# Altium schematic sheet Debug.SchDoc
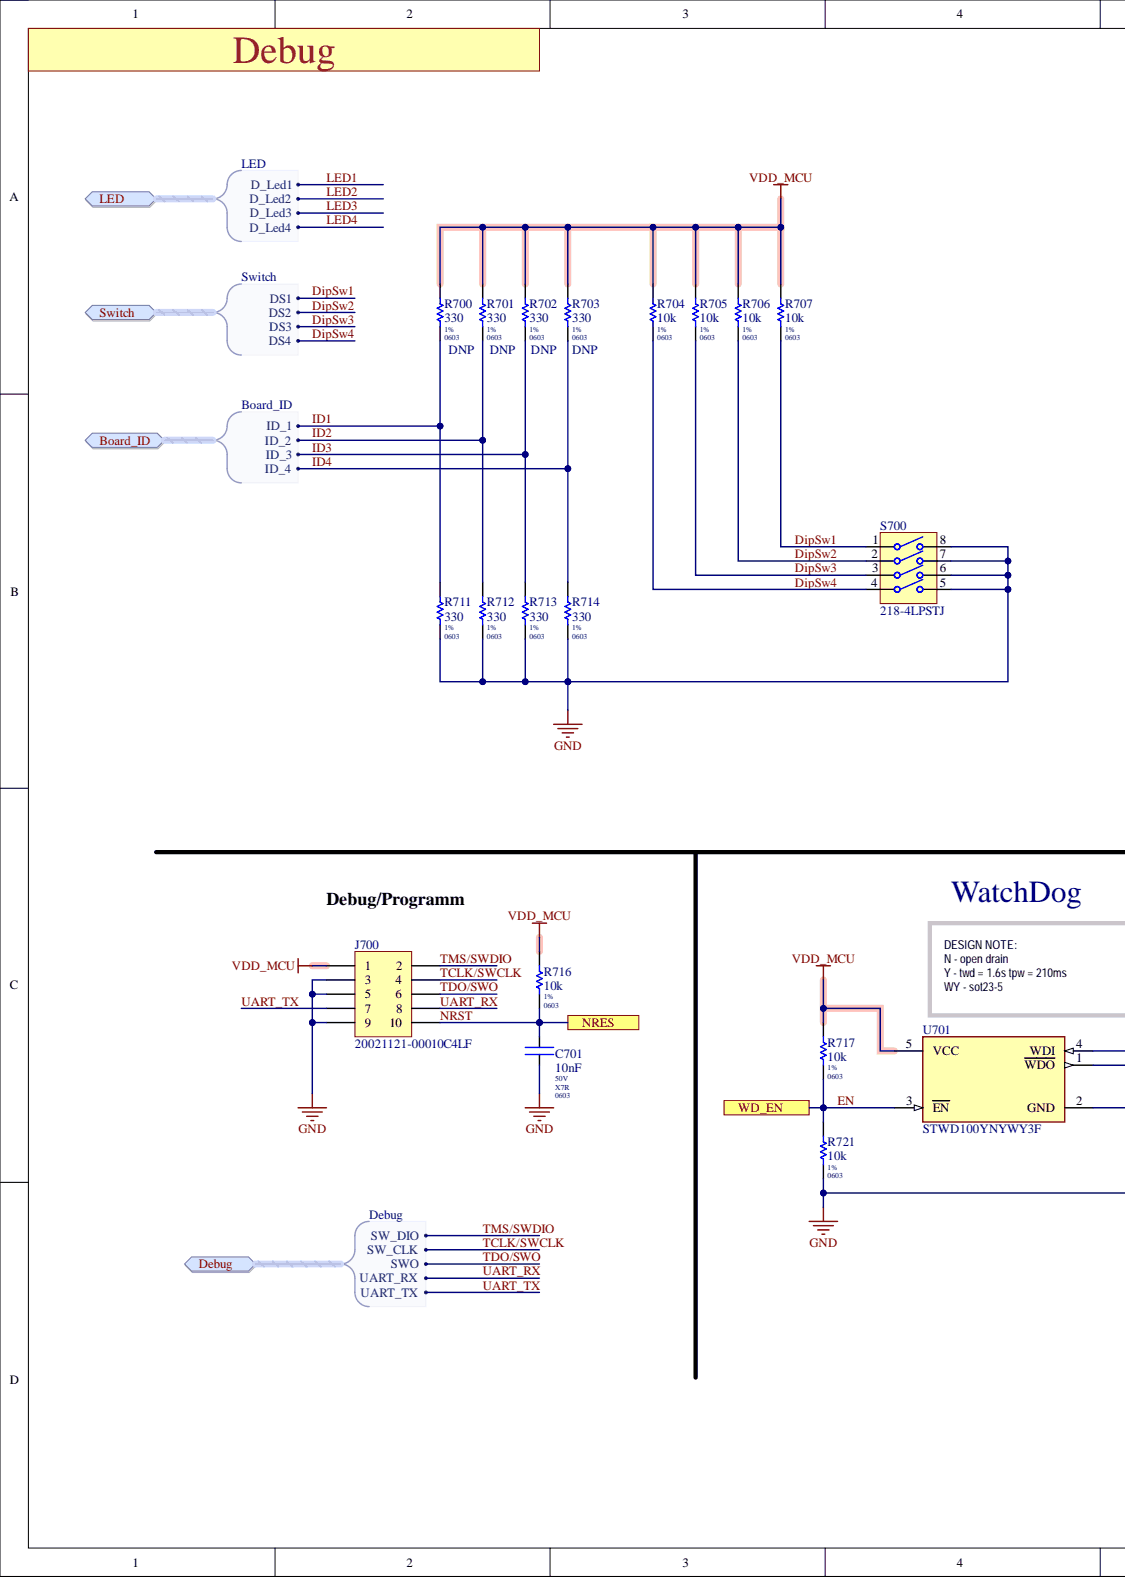
[diagram p0: Debug.SchDoc, left]
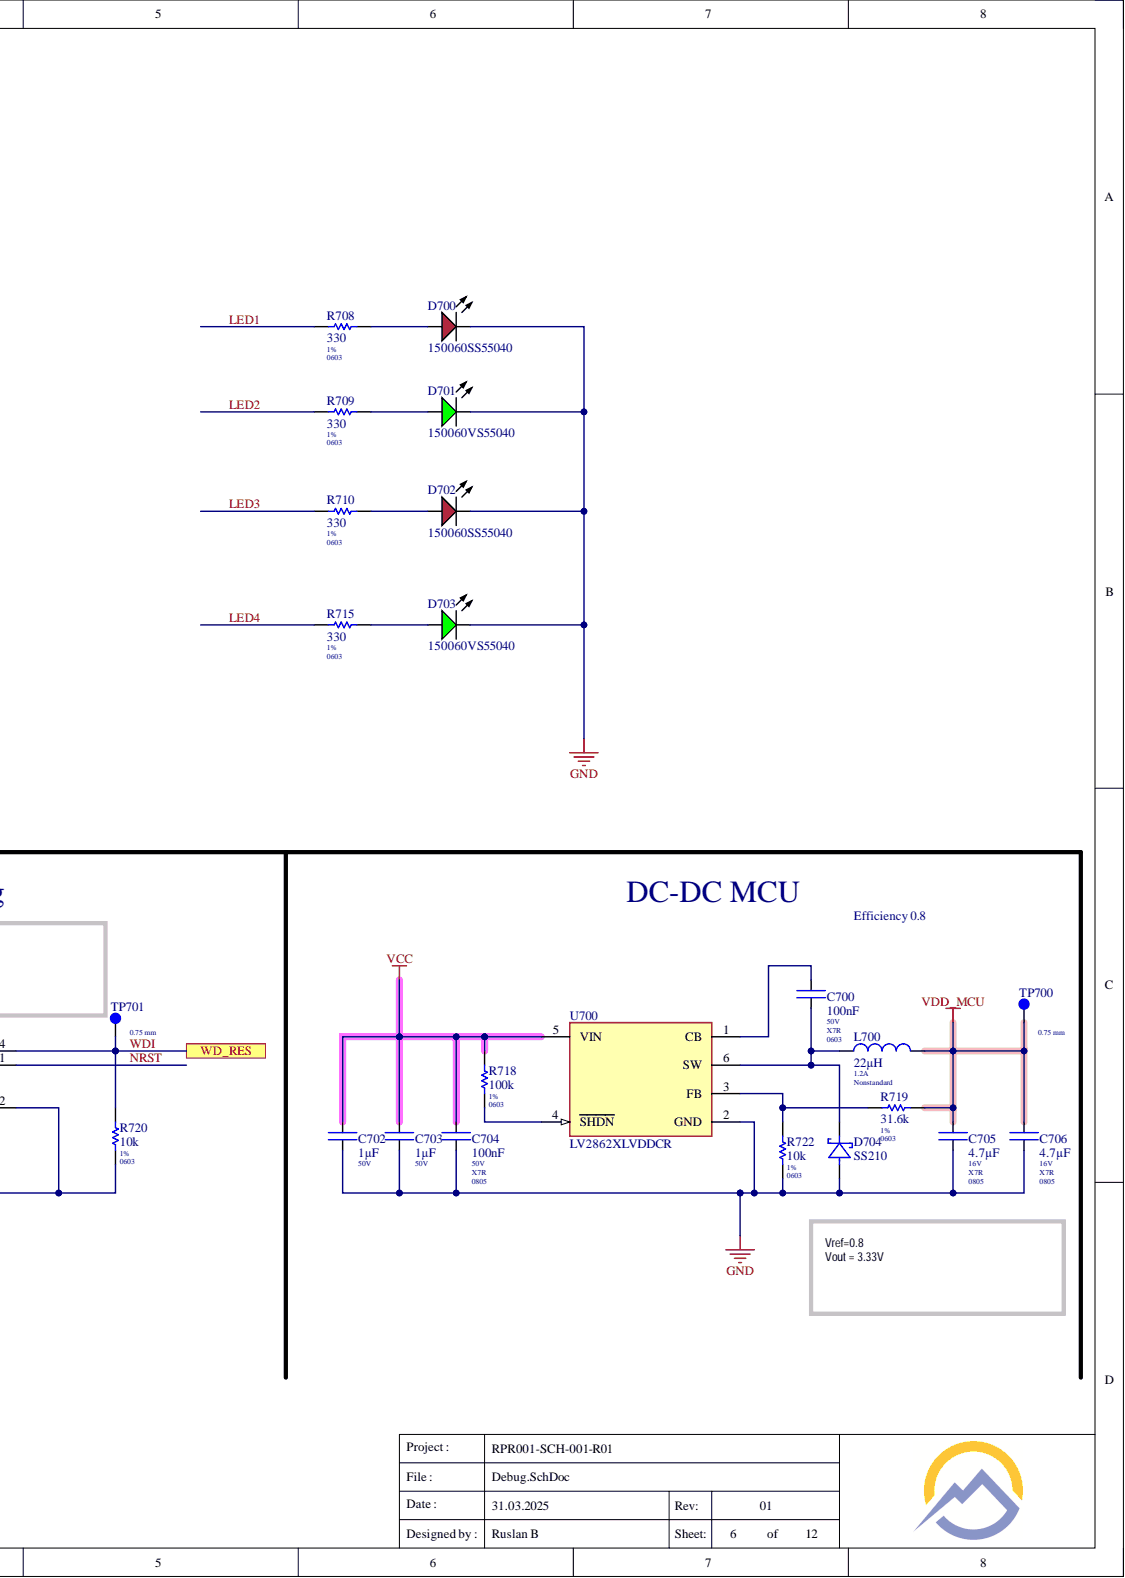
[diagram p1: Debug.SchDoc, right]

## Parts
C700  value 100nF  Voltage Rating: 50V  [B_Cap_0603_KEM]  (hidden comment: GCM188R71H104KA57D)
C701  value 10nF  Case/Package: 0603  Dielectric: X7R  Voltage Rating: 50V  [B_Cap_0603_KEM]  (hidden comment: CC0603MRX7R9BB103)
C702  value 1µF  Voltage Rating: 50V  [B_Cap_0805_KEM]  (hidden comment: CL21B105KBFNNNG)
C703  value 1µF  Voltage Rating: 50V  [B_Cap_0805_KEM]  (hidden comment: CL21B105KBFNNNG)
C704  value 100nF  Case/Package: 0805  Dielectric: X7R  Voltage Rating: 50V  [B_Cap_0805_KEM]  (hidden comment: CC0805KRX7R9BB104)
C705  value 4.7µF  Case/Package: 0805  Dielectric: X7R  Voltage Rating: 16V  [B_Cap_0805_KEM]  (hidden comment: GRM21BR71C475KE51L)
C706  value 4.7µF  Case/Package: 0805  Dielectric: X7R  Voltage Rating: 16V  [B_Cap_0805_KEM]  (hidden comment: GRM21BR71C475KE51L)
D700  150060SS55040  [LED_0603]
D701  150060VS55040  [LED_0603]
D702  150060SS55040  [LED_0603]
D703  150060VS55040  [LED_0603]
D704  SS210  [DO-214AA, SMB]
J700  20021121-00010C4LF  [20021121-00010C4LF-Footprint-2]
L700  value 22µH  Current Rating: 1.2A  [CDRH6D28NP]  (hidden comment: CDRH6D28NP-220NC)
R700  value 330  Assembly: DNP  Case/Package: 0603  Tolerance: 1%  [Res_0603_YAG]  (hidden comment: RC0603FR-07330RL)
R701  value 330  Assembly: DNP  Case/Package: 0603  Tolerance: 1%  [Res_0603_YAG]  (hidden comment: RC0603FR-07330RL)
R702  value 330  Assembly: DNP  Case/Package: 0603  Tolerance: 1%  [Res_0603_YAG]  (hidden comment: RC0603FR-07330RL)
R703  value 330  Assembly: DNP  Case/Package: 0603  Tolerance: 1%  [Res_0603_YAG]  (hidden comment: RC0603FR-07330RL)
R704  value 10k  Case/Package: 0603  Tolerance: 1%  [Res_0603_YAG]  (hidden comment: RC0603FR-0710KL)
R705  value 10k  Case/Package: 0603  Tolerance: 1%  [Res_0603_YAG]  (hidden comment: RC0603FR-0710KL)
R706  value 10k  Case/Package: 0603  Tolerance: 1%  [Res_0603_YAG]  (hidden comment: RC0603FR-0710KL)
R707  value 10k  Case/Package: 0603  Tolerance: 1%  [Res_0603_YAG]  (hidden comment: RC0603FR-0710KL)
R708  value 330  Case/Package: 0603  Tolerance: 1%  [Res_0603_YAG]  (hidden comment: RC0603FR-07330RL)
R709  value 330  Case/Package: 0603  Tolerance: 1%  [Res_0603_YAG]  (hidden comment: RC0603FR-07330RL)
R710  value 330  Case/Package: 0603  Tolerance: 1%  [Res_0603_YAG]  (hidden comment: RC0603FR-07330RL)
R711  value 330  Case/Package: 0603  Tolerance: 1%  [Res_0603_YAG]  (hidden comment: RC0603FR-07330RL)
R712  value 330  Case/Package: 0603  Tolerance: 1%  [Res_0603_YAG]  (hidden comment: RC0603FR-07330RL)
R713  value 330  Case/Package: 0603  Tolerance: 1%  [Res_0603_YAG]  (hidden comment: RC0603FR-07330RL)
R714  value 330  Case/Package: 0603  Tolerance: 1%  [Res_0603_YAG]  (hidden comment: RC0603FR-07330RL)
R715  value 330  Case/Package: 0603  Tolerance: 1%  [Res_0603_YAG]  (hidden comment: RC0603FR-07330RL)
R716  value 10k  Case/Package: 0603  Tolerance: 1%  [Res_0603_YAG]  (hidden comment: RC0603FR-0710KL)
R717  value 10k  Case/Package: 0603  Tolerance: 1%  [Res_0603_YAG]  (hidden comment: RC0603FR-0710KL)
R718  value 100k  Case/Package: 0603  Tolerance: 1%  [Res_0603_YAG]  (hidden comment: RMCF0603FT100K)
R719  value 31.6k  [Res_0603_YAG]  (hidden comment: RMCF0603FT31K6)
R720  value 10k  Case/Package: 0603  Tolerance: 1%  [Res_0603_YAG]  (hidden comment: RC0603FR-0710KL)
R721  value 10k  Case/Package: 0603  Tolerance: 1%  [Res_0603_YAG]  (hidden comment: RC0603FR-0710KL)
R722  value 10k  Case/Package: 0603  Tolerance: 1%  [Res_0603_YAG]  (hidden comment: RC0603FR-0710KL)
S700  218-4LPSTJ  [218-4LPSTJ]
TP700  0.75 mm  [0.75mm]  (no BOM)
TP701  0.75 mm  [0.75mm]  (no BOM)
U700  LV2862XLVDDCR  [SOT-23-6]
U701  STWD100YNYWY3F  [SOT23-5 ST]

## Ports
Board_ID, Board_ID.ID_1, Board_ID.ID_2, Board_ID.ID_3, Board_ID.ID_4, Debug, Debug.SW_CLK, Debug.SW_DIO, Debug.SWO, Debug.UART_RX, Debug.UART_TX, LED, LED.D_Led1, LED.D_Led2, LED.D_Led3, LED.D_Led4, NRES, Switch, Switch.DS1, Switch.DS2, Switch.DS3, Switch.DS4, WD_EN, WD_RES

## Nets
GND: C701.1 C702.2 C703.2 C704.1 C705.1 C706.1 D700.1 D701.1 D702.1 D703.1 D704.2 J700.3 J700.5 J700.9 R711.1 R712.1 R713.1 R714.1 R720.1 R721.1 R722.1 S700.5 S700.6 S700.7 S700.8 U700.2(GND) U701.2(GND)
VCC: C702.1 C703.1 C704.2 R718.2 U700.5(VIN)
VDD_MCU: C705.2 C706.2 J700.1 L700.2 R700.2 R701.2 R702.2 R703.2 R704.2 R705.2 R706.2 R707.2 R716.2 R717.2 R719.2 TP700.1 U701.5(VCC)
DipSw1: R707.1 S700.1 ; port Switch
DipSw2: R706.1 S700.2 ; port Switch
DipSw3: R705.1 S700.3 ; port Switch
DipSw4: R704.1 S700.4 ; port Switch
EN: R717.1 R721.2 U701.3(EN) ; port WD_EN
ID1: R700.1 R711.2 ; port Board_ID
ID2: R701.1 R712.2 ; port Board_ID
ID3: R702.1 R713.2 ; port Board_ID
ID4: R703.1 R714.2 ; port Board_ID
LED1: R708.1 ; port LED
LED2: R709.1 ; port LED
LED3: R710.1 ; port LED
LED4: R715.1 ; port LED
NRST: C701.2 J700.10 R716.1 U701.1(WDO) ; port NRES
TCLK/SWCLK: J700.4 ; port Debug
TDO/SWO: J700.6 ; port Debug
TMS/SWDIO: J700.2 ; port Debug
UART_RX: J700.8 ; port Debug
UART_TX: J700.7 ; port Debug
WDI: R720.2 TP701.1 U701.4(WDI) ; port WD_RES
NetC700_1: C700.1 D704.1 L700.1 U700.6(SW)
NetC700_2: C700.2 U700.1(CB)
NetD700_2: D700.2 R708.2
NetD701_2: D701.2 R709.2
NetD702_2: D702.2 R710.2
NetD703_2: D703.2 R715.2
NetR718_1: R718.1 U700.4(SHDN)
NetR719_1: R719.1 R722.2 U700.3(FB)

## Library data of the parts
C700 = CMP-CAP-CER-00027-13: CAP CER 0.1UF 50V X7R 0603; Manufacturer 1: Murata; Tolerance: ±10%; Case/Package: 0603; Component Kind: Standard; ComponentLink1Description: Datasheet; ComponentLink1URL: https://search.murata.co.jp/Ceramy/image/img/A01X/G101/ENG/GCM188R71H104KA57-01.pdf; Dielectric: X7R; Library Name: <Company> Server; Manufacturer Part Number 1: GCM188R71H104KA57D
C701 = CMP-CAP-CER-00261-3: 0603 10 nF 50 V ±20% Tolerance X7R SMT Multilayer Ceramic Capacitor; Manufacturer 1: Yageo; Component Kind: Standard; Library Name: <Company> Server; Manufacturer Part Number 1: CC0603MRX7R9BB103; Tolerance: 20%
C702 C703 = CMP-CAP-CER-00135-12: CAP CER 1UF 50V X7R 0805; Manufacturer 1: Samsung; Tolerance: ±10%; Component Kind: Standard; ComponentLink1Description: Datasheet; ComponentLink1URL: http://www.samsungsem.com/kr/support/product-search/mlcc/CL21B105KBFNNNG.jsp; Library Name: <Company> Server; Manufacturer Part Number 1: CL21B105KBFNNNG
C704 = CMP-CAP-CER-00029-14: Multilayer Ceramic Capacitor, 0.1 uF, 50 V, ï¿½ 10%, X7R, 0805 [2012 Metric]; Manufacturer 1: YAGEO; Component Kind: Standard; Library Name: <Company> Server; Manufacturer Part Number 1: CC0805KRX7R9BB104; Tolerance: 10%
C705 C706 = CMP-CAP-CER-00204-11: CAP CER 4.7UF 16V X7R 0805; Manufacturer 1: Murata; Tolerance: ±10%; Component Kind: Standard; ComponentLink1Description: Datasheet; ComponentLink1URL: https://search.murata.co.jp/Ceramy/image/img/A01X/G101/ENG/GRM21BR71C475KE51-01.pdf; Library Name: <Company> Server; Manufacturer Part Number 1: GRM21BR71C475KE51L
D700 D702 = CMP-OPTE-LED-00052-3: Led Red Diffused 0603 SMD; Manufacturer 1: Wurth Electronics; Dominant Wavelength: 630nm; Forward Voltage: 2V; Lens Style: Flat; Luminous Intensity: 70mcd; Viewing Angle: 140°; Case/Package: 0603; Color: Red; Component Kind: Standard; ComponentLink1Description: Datasheet; ComponentLink1URL: https://www.we-online.de/katalog/datasheet/150060RS75000.pdf; Library Name: <Company> Server; Manufacturer Part Number 1: 150060SS55040
D701 D703 = CMP-OPTE-LED-00055-3: Led Green Diffused 0603 SMD; Manufacturer 1: Wurth Electronics; Dominant Wavelength: 573nm; Forward Voltage: 2V; Lens Style: Flat; Luminous Intensity: 60mcd; Viewing Angle: 140°; Case/Package: 0603; Color: Green; Component Kind: Standard; ComponentLink1Description: Datasheet; ComponentLink1URL: https://www.rohm.com/datasheet/SML-D12V8W; Library Name: <Company> Server; Manufacturer Part Number 1: 150060VS55040
D704 = CMP-DIO-SCH-00037-8: DIODE SCHOTTKY 100V 2A SMA; Manufacturer 1: Taiwan Semiconductor; Forward Voltage: 850mV; Max Reverse Current: 100µA; Average Rectified Current: 2A; Case/Package: DO-214AA; Component Kind: Standard; ComponentLink1Description: Datasheet; ComponentLink1URL: http://www.smc-diodes.com/propdf/SS22A%20THRU%20SS225A%20N1816%20REV.A.pdf; Forward Current: 2A; Library Name: <Company> Server; Manufacturer Part Number 1: SS210; Max Reverse Voltage (DC): 100V
J700 = CMP-CON-HEAD-00050-8: CONN HEADER SMD 10POS 1.27MM; Manufacturer 1: Amphenol ICC / FCI; Current Rating: 1A; Gender: Male; Number of Contacts: 10; Component Kind: Standard; ComponentLink1Description: Datasheet; ComponentLink1URL: https://media.digikey.com/pdf/Data%20Sheets/FCI%20PDFs/Minitek%20127_Catalog.pdf; Library Name: <Company> Server; Manufacturer Part Number 1: 20021121-00010C4LF; Number of Positions: 10; Number of Rows: 2; Pitch: 1.27mm
L700 = CMP-IND-00067-9: FIXED IND 22UH 1.2A 128 MOHM SMD; Manufacturer 1: Sumida; DC Resistance (DCR): 0.128; Shielding: Shielded; Tolerance: 30%; Case/Package: Nonstandard; Component Kind: Standard; ComponentLink1Description: Datasheet; ComponentLink1URL: http://products.sumida.com/products/pdf/CDRH6D28.pdf; Library Name: <Company> Server; Manufacturer Part Number 1: CDRH6D28NP-220NC
R700-R703 R708-R715 = CMP-RES-SMD-00510-2: SMD Chip Resistor, 330 Ohm, ± 1%, 100 mW, 0603 [1608 Metric], Thick Film, General Purpose; Manufacturer 1: Yageo; Power Rating: 100mW; Temperature Coefficient: 100ppm/°C; Voltage Rating: 50V; Component Kind: Standard; Library Name: <Company> Server; Manufacturer Part Number 1: RC0603FR-07330RL
R704-R707 R716 R717 R720-R722 = CMP-RES-SMD-00183-9: RES SMD 10K OHM 1% 1/10W 0603; Manufacturer 1: Yageo; Temperature Coefficient: ±100ppm/℃; Component Kind: Standard; ComponentLink1Description: Datasheet; ComponentLink1URL: https://www.yageo.com/upload/media/product/productsearch/datasheet/rchip/PYu-RC_Group_51_RoHS_L_10.pdf; Library Name: <Company> Server; Manufacturer Part Number 1: RC0603FR-0710KL
R718 = CMP-RES-SMD-00047-11: RES 100K OHM 1% 1/10W 0603; Manufacturer 1: Stackpole Electronics; Temperature Coefficient: ±100ppm/℃; Component Kind: Standard; ComponentLink1Description: Datasheet; ComponentLink1URL: https://www.seielect.com/catalog/sei-rmcf_rmcp.pdf; Library Name: <Company> Server; Manufacturer Part Number 1: RMCF0603FT100K
R719 = CMP-RES-SMD-00091-11: RES 31.6K OHM 1% 1/10W 0603; Manufacturer 1: Stackpole Electronics; Temperature Coefficient: ±100ppm/℃; Case/Package: 0603; Component Kind: Standard; ComponentLink1Description: Datasheet; ComponentLink1URL: https://www.seielect.com/catalog/sei-rmcf_rmcp.pdf; Library Name: <Company> Server; Manufacturer Part Number 1: RMCF0603FT31K6; Tolerance: 1%
S700 = CMP-SW-TSW-00009-3: SWITCH SLIDE DIP SPST 25MA 24V; Manufacturer 1: CTS; Component Kind: Standard; ComponentLink1Description: Datasheet; ComponentLink1URL: https://www.digikey.com/product-detail/en/cts-electrocomponents/218-4LPSTJ/CT3109-ND/5223842; Contact Current Rating: 25mA; Current Rating: 25mA; Library Name: <Company> Server; Manufacturer Part Number 1: 218-4LPSTJ; Switch Function: SPST; Voltage Rating (DC): 24V
TP700 TP701 = CMP-MF-TP-00003-4: Test point 0.75 mm; Library Name: <Company> Server; Size: 0.75 mm
U700 = CMP-POW-VOLR-SW-00041-4: 4.5-V to 60-V, 600-mA buck converter in ultra-small SOT23 package 6-SOT-23-THIN -40 to 125; Manufacturer 1: Texas Instruments; Max Input Voltage: 60V; Min Input Voltage: 4V; Output Current: 600mA; Output Type: Adjustable; Switching Frequency: 2.1MHz; Synchronous Rectifier: No; Case/Package: SOT-23-6 Thin, TSOT-23-6; Component Kind: Standard; Library Name: <Company> Server; Manufacturer Part Number 1: LV2862XLVDDCR; Output Voltage: 60V
U701 = CMP-IC-OTH-00008-1: Processor Supervisor 1 Open Drain/Push-Pull Automotive 5-Pin SOT-23 T/R; Max Supply Voltage: 5.5V; Min Supply Voltage: 2.7V; Case/Package: SOT-23-5; Component Kind: Standard; Library Name: <Company> Server; Type: Watchdog Circuit
